# Altium schematic sheet TFT2.5inch.SchDoc
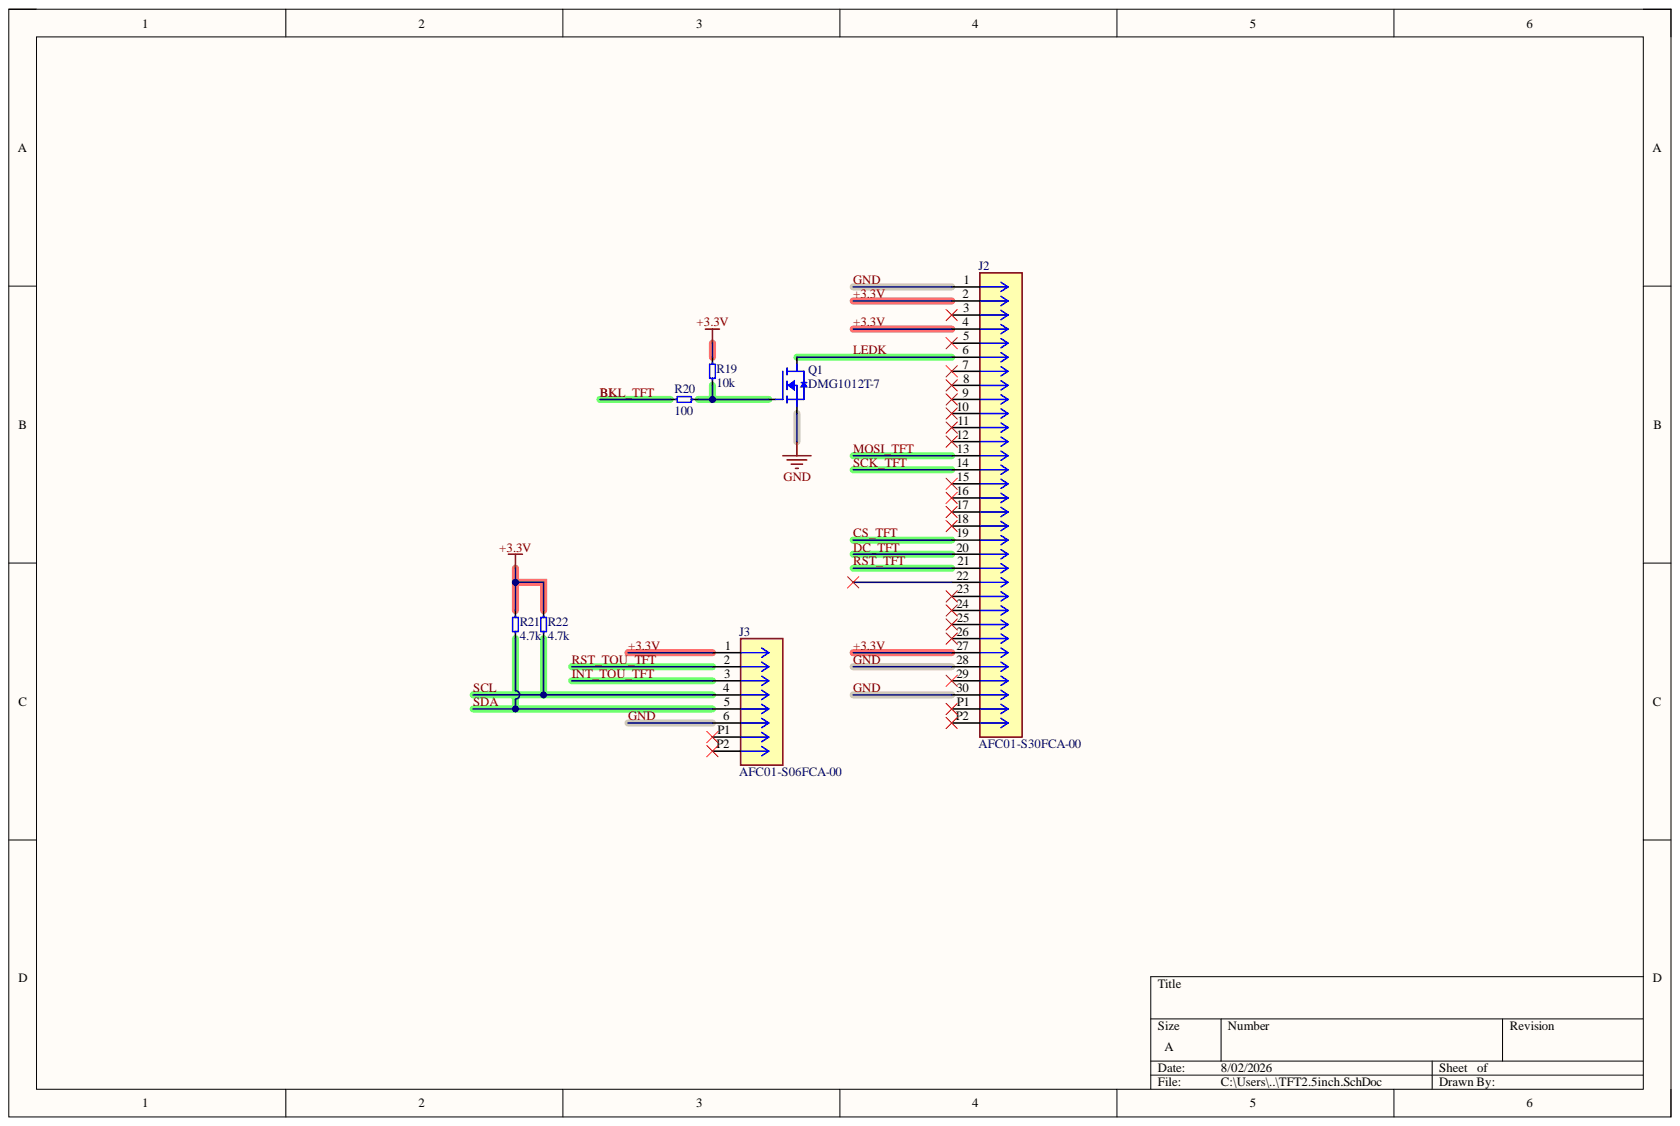
[diagram p0: TFT2.5inch.SchDoc]

## Parts
J2  AFC01-S30FCA-00  [AFC01-S30FCA-00]
J3  AFC01-S06FCA-00  [FFC06P0.5MM]
Q1  DMG1012T-7  [SOT523]
R19  10k  [RES_0402]
R20  100  [RES_0402]
R21  4.7k  [RES_0402]
R22  4.7k  [RES_0402]

## Nets
+3.3V: J2.2 J2.4 J2.27 J3.1 R19.2 R21.2 R22.2
BKL_TFT: R20.1
CS_TFT: J2.19
DC_TFT: J2.20
GND: J2.1 J2.28 J2.30 J3.6 Q1.2
INT_TOU_TFT: J3.3
LEDK: J2.6 Q1.3
MOSI_TFT: J2.13
RST_TFT: J2.21
RST_TOU_TFT: J3.2
SCK_TFT: J2.14
SCL: J3.4 R22.1
SDA: J3.5 R21.1
NetQ1_1: Q1.1 R19.1 R20.2

Not connected: J2 3 5 7 8 9 10 11 12 15 16 17 18 22 23 24 25 26 29 P1 P2; J3 P1 P2

## Library data of the parts
J2 = AFC01-S30FCA-00: Hinged Lid 30P Bottom Contact Surface Mount, Right Angle 0.5mm SMD,P=0.5mm,Surface Mount,Right Angle FFC, FPC (Flat Flexible) Connector Assemblies ROHS; MF: Molex; MP: 5051103091; Check_prices: https://www.snapeda.com/parts/5051103091/Molex/view-part/?ref=eda; Component Kind: Standard; Package: None; SnapEDA_Link: https://www.snapeda.com/parts/5051103091/Molex/view-part/?ref=snap; Library Name: RQSchlib.SchLib
J3 = AFC01-S06FCA-00_1: 0.3mm 0.5mm 2mm 6P Bottom Contact Hinged Lid Surface Mount, Right Angle SMD,P=0.5mm,Surface Mount,Right Angle FFC, FPC (Flat Flexible) Connector Assemblies ROHS; MF: Molex; MP: 527460671; Check_prices: https://www.snapeda.com/parts/527460671/Molex/view-part/?ref=eda; Component Kind: Standard; Package: None; SnapEDA_Link: https://www.snapeda.com/parts/527460671/Molex/view-part/?ref=snap; Library Name: RQSchlib.SchLib
Q1 = DMG1012T-7: 20V 630mA 280mW 1V@250uA 1 N-Channel SOT-523 MOSFETs ROHS; MF: Diotec Semiconductor; MP: 2N7002; Check_prices: https://www.snapeda.com/parts/2N7002/Diotec/view-part/?ref=eda; Component Kind: Standard; Package: SOT-23 Diotec; SnapEDA_Link: https://www.snapeda.com/parts/2N7002/Diotec/view-part/?ref=snap; Library Name: RQSchlib.SchLib
R19-R22 = RES_0402: Component Kind: Standard; Library Name: RQSchlib.SchLib
